# Altium schematic sheet S7CAS-PLEIADES-CARTE-MERE_P07_CLOCK.SchDoc(S7CAS-PLEIADES-CARTE-MERE_P07_CLOCK)
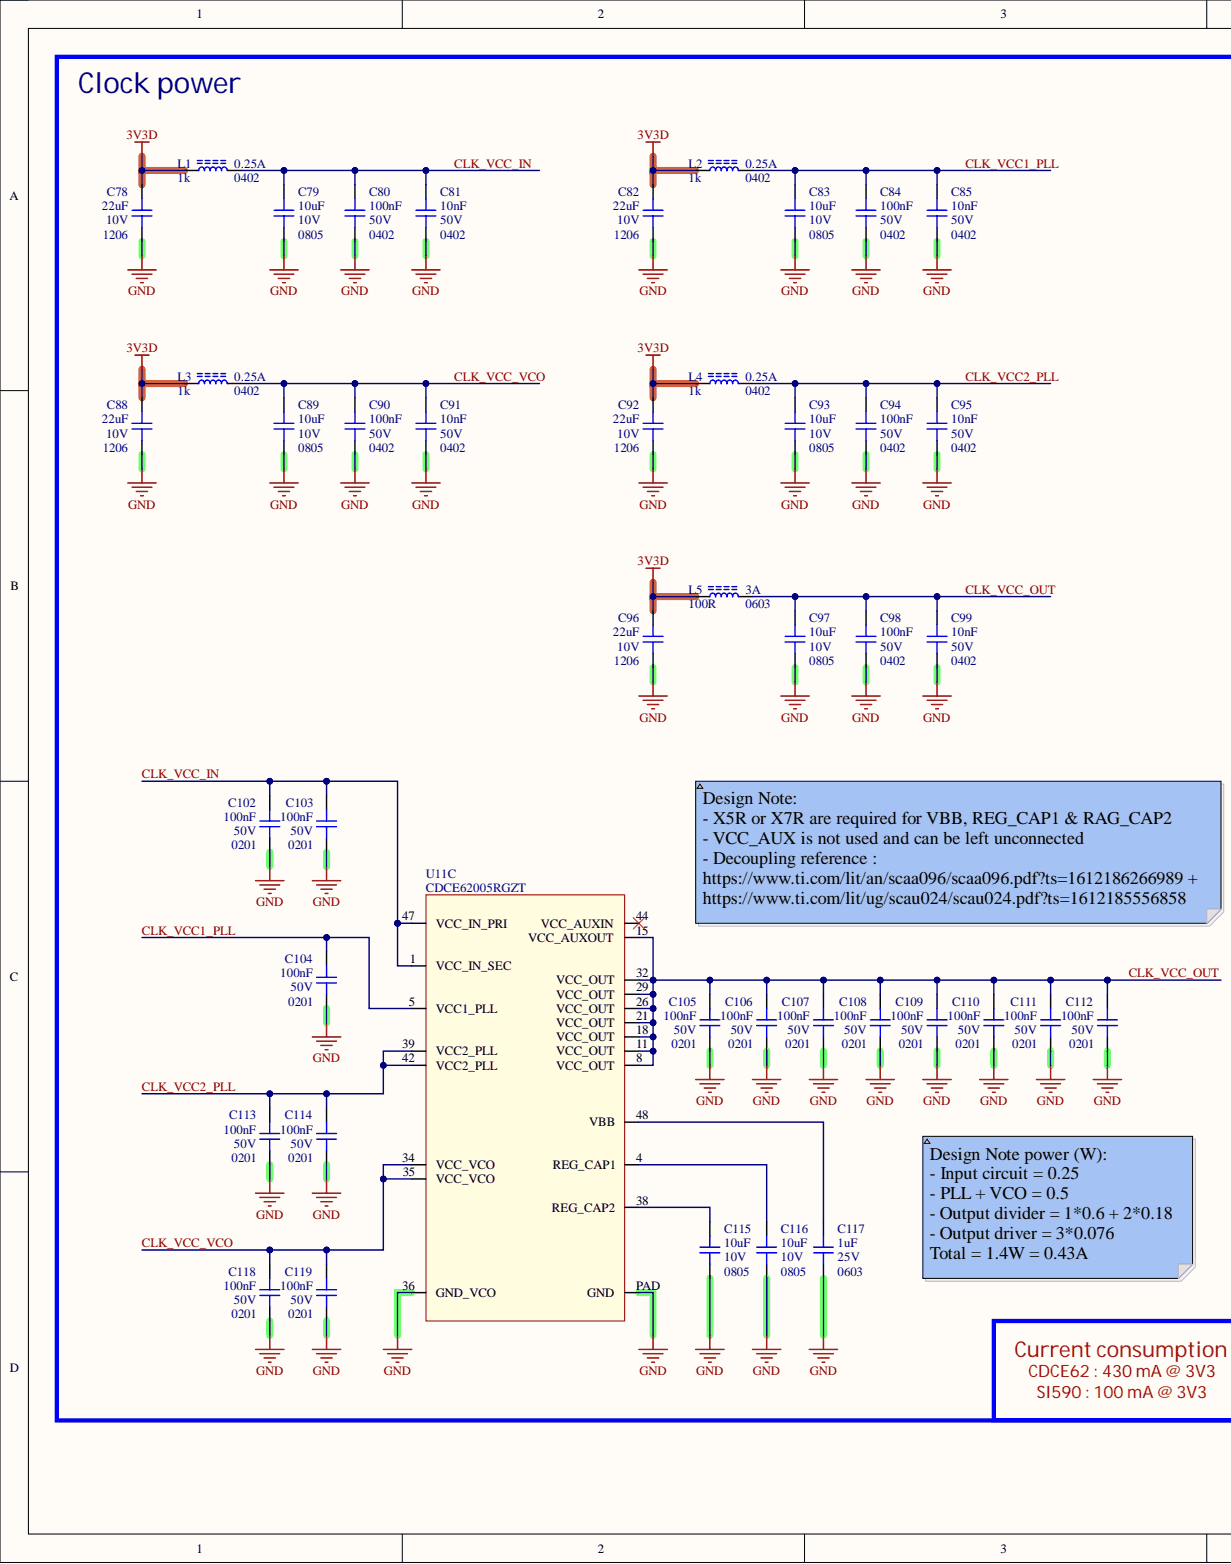
[diagram p0: S7CAS-PLEIADES-CARTE-MERE_P07_CLOCK.SchDoc, left]
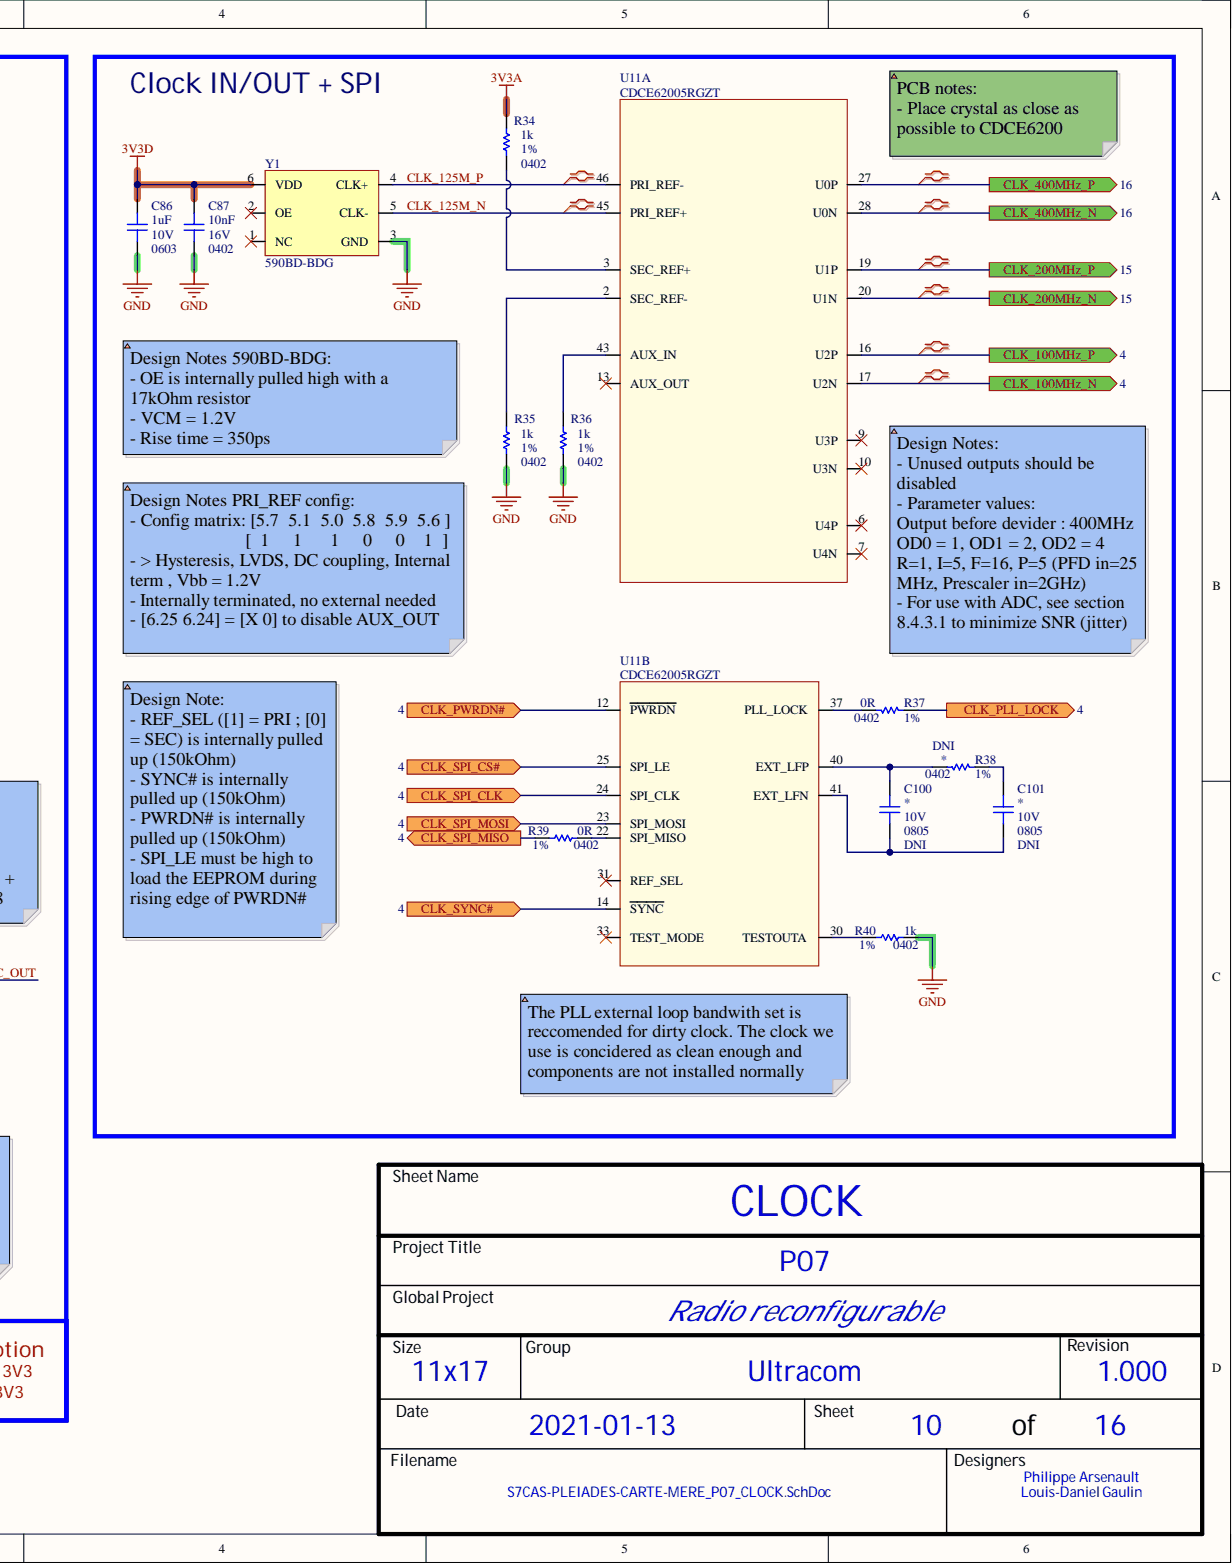
[diagram p1: S7CAS-PLEIADES-CARTE-MERE_P07_CLOCK.SchDoc, right]

## Parts
C78  value 22uF  Package: 1206  Voltage: 10V  [CAP_1206]  (hidden comment: CAP - 1206)
C79  value 10uF  Package: 0805  Voltage: 10V  [CAP_0805]  (hidden comment: CAP - 0805)
C80  value 100nF  Package: 0402  Voltage: 50V  [CAP_0402]  (hidden comment: CAP - 0402)
C81  value 10nF  Package: 0402  Voltage: 50V  [CAP_0402]  (hidden comment: CAP - 0402)
C82  value 22uF  Package: 1206  Voltage: 10V  [CAP_1206]  (hidden comment: CAP - 1206)
C83  value 10uF  Package: 0805  Voltage: 10V  [CAP_0805]  (hidden comment: CAP - 0805)
C84  value 100nF  Package: 0402  Voltage: 50V  [CAP_0402]  (hidden comment: CAP - 0402)
C85  value 10nF  Package: 0402  Voltage: 50V  [CAP_0402]  (hidden comment: CAP - 0402)
C86  value 1uF  Package: 0603  Voltage: 10V  [CAP_0603]  (hidden comment: CAP - 0603)
C87  value 10nF  Package: 0402  Voltage: 16V  [CAP_0402]  (hidden comment: CAP - 0402)
C88  value 22uF  Package: 1206  Voltage: 10V  [CAP_1206]  (hidden comment: CAP - 1206)
C89  value 10uF  Package: 0805  Voltage: 10V  [CAP_0805]  (hidden comment: CAP - 0805)
C90  value 100nF  Package: 0402  Voltage: 50V  [CAP_0402]  (hidden comment: CAP - 0402)
C91  value 10nF  Package: 0402  Voltage: 50V  [CAP_0402]  (hidden comment: CAP - 0402)
C92  value 22uF  Package: 1206  Voltage: 10V  [CAP_1206]  (hidden comment: CAP - 1206)
C93  value 10uF  Package: 0805  Voltage: 10V  [CAP_0805]  (hidden comment: CAP - 0805)
C94  value 100nF  Package: 0402  Voltage: 50V  [CAP_0402]  (hidden comment: CAP - 0402)
C95  value 10nF  Package: 0402  Voltage: 50V  [CAP_0402]  (hidden comment: CAP - 0402)
C96  value 22uF  Package: 1206  Voltage: 10V  [CAP_1206]  (hidden comment: CAP - 1206)
C97  value 10uF  Package: 0805  Voltage: 10V  [CAP_0805]  (hidden comment: CAP - 0805)
C98  value 100nF  Package: 0402  Voltage: 50V  [CAP_0402]  (hidden comment: CAP - 0402)
C99  value 10nF  Package: 0402  Voltage: 50V  [CAP_0402]  (hidden comment: CAP - 0402)
C100  NI: DNI  Package: 0805  Voltage: 10V  [CAP_0805]  (hidden comment: CAP - 0805)
C101  NI: DNI  Package: 0805  Voltage: 10V  [CAP_0805]  (hidden comment: CAP - 0805)
C102  value 100nF  Package: 0201  Voltage: 50V  [CAP_0201]  (hidden comment: CAP - 0201)
C103  value 100nF  Package: 0201  Voltage: 50V  [CAP_0201]  (hidden comment: CAP - 0201)
C104  value 100nF  Package: 0201  Voltage: 50V  [CAP_0201]  (hidden comment: CAP - 0201)
C105  value 100nF  Package: 0201  Voltage: 50V  [CAP_0201]  (hidden comment: CAP - 0201)
C106  value 100nF  Package: 0201  Voltage: 50V  [CAP_0201]  (hidden comment: CAP - 0201)
C107  value 100nF  Package: 0201  Voltage: 50V  [CAP_0201]  (hidden comment: CAP - 0201)
C108  value 100nF  Package: 0201  Voltage: 50V  [CAP_0201]  (hidden comment: CAP - 0201)
C109  value 100nF  Package: 0201  Voltage: 50V  [CAP_0201]  (hidden comment: CAP - 0201)
C110  value 100nF  Package: 0201  Voltage: 50V  [CAP_0201]  (hidden comment: CAP - 0201)
C111  value 100nF  Package: 0201  Voltage: 50V  [CAP_0201]  (hidden comment: CAP - 0201)
C112  value 100nF  Package: 0201  Voltage: 50V  [CAP_0201]  (hidden comment: CAP - 0201)
C113  value 100nF  Package: 0201  Voltage: 50V  [CAP_0201]  (hidden comment: CAP - 0201)
C114  value 100nF  Package: 0201  Voltage: 50V  [CAP_0201]  (hidden comment: CAP - 0201)
C115  value 10uF  Package: 0805  Voltage: 10V  [CAP_0805]  (hidden comment: CAP - 0805)
C116  value 10uF  Package: 0805  Voltage: 10V  [CAP_0805]  (hidden comment: CAP - 0805)
C117  value 1uF  Package: 0603  Voltage: 25V  [CAP_0603]  (hidden comment: CAP - 0603)
C118  value 100nF  Package: 0201  Voltage: 50V  [CAP_0201]  (hidden comment: CAP - 0201)
C119  value 100nF  Package: 0201  Voltage: 50V  [CAP_0201]  (hidden comment: CAP - 0201)
L1  value 1k  Current: 0.25A  Package: 0402  [FER_0402]  (hidden comment: FER - 0402)
L2  value 1k  Current: 0.25A  Package: 0402  [FER_0402]  (hidden comment: FER - 0402)
L3  value 1k  Current: 0.25A  Package: 0402  [FER_0402]  (hidden comment: FER - 0402)
L4  value 1k  Current: 0.25A  Package: 0402  [FER_0402]  (hidden comment: FER - 0402)
L5  value 100R  Current: 3A  Package: 0603  [FER_0603]  (hidden comment: FER - 0603)
R34  value 1k  Package: 0402  Precision: 1%  [RES_0402]  (hidden comment: RES - 0402)
R35  value 1k  Package: 0402  Precision: 1%  [RES_0402]  (hidden comment: RES - 0402)
R36  value 1k  Package: 0402  Precision: 1%  [RES_0402]  (hidden comment: RES - 0402)
R37  value 0R  Package: 0402  Precision: 1%  [RES_0402]  (hidden comment: RES - 0402)
R38  NI: DNI  Package: 0402  Precision: 1%  [RES_0402]  (hidden comment: RES - 0402)
R39  value 0R  Package: 0402  Precision: 1%  [RES_0402]  (hidden comment: RES - 0402)
R40  value 1k  Package: 0402  Precision: 1%  [RES_0402]  (hidden comment: RES - 0402)
U11  CDCE62005RGZT  [TIM_CDCE62005RGZT]  (parts A,B,C)
Y1  590BD-BDG  [OSC_590BD-BDG]

## Ports
CLK_100MHz_N, CLK_100MHz_P, CLK_200MHz_N, CLK_200MHz_P, CLK_400MHz_N, CLK_400MHz_P, CLK_PLL_LOCK, CLK_PWRDN#, CLK_SPI_CLK, CLK_SPI_CS#, CLK_SPI_MISO, CLK_SPI_MOSI, CLK_SYNC#

## Nets
3V3A: R34.2
3V3D: C78.2 C82.2 C86.2 C87.2 C88.2 C92.2 C96.2 L1.2 L2.2 L3.2 L4.2 L5.2 Y1.6(VDD)
GND: C78.1 C79.1 C80.1 C81.1 C82.1 C83.1 C84.1 C85.1 C86.1 C87.1 C88.1 C89.1 C90.1 C91.1 C92.1 C93.1 C94.1 C95.1 C96.1 C97.1 C98.1 C99.1 C102.1 C103.1 C104.1 C105.1 C106.1 C107.1 C108.1 C109.1 C110.1 C111.1 C112.1 C113.1 C114.1 C115.1 C116.1 C117.1 C118.1 C119.1 R35.1 R36.1 R40.1 U11.36(GND_VCO) U11.PAD(GND) Y1.3(GND)
CLK_100MHz_N: U11.17(U2N) ; port CLK_100MHz_N
CLK_100MHz_P: U11.16(U2P) ; port CLK_100MHz_P
CLK_125M_N: U11.45(PRI_REF+) Y1.5(CLK-)
CLK_125M_P: U11.46(PRI_REF-) Y1.4(CLK+)
CLK_200MHz_N: U11.20(U1N) ; port CLK_200MHz_N
CLK_200MHz_P: U11.19(U1P) ; port CLK_200MHz_P
CLK_400MHz_N: U11.28(U0N) ; port CLK_400MHz_N
CLK_400MHz_P: U11.27(U0P) ; port CLK_400MHz_P
CLK_PLL_LOCK: R37.2 ; port CLK_PLL_LOCK
CLK_PWRDN#: U11.12(PWRDN) ; port CLK_PWRDN#
CLK_SPI_CLK: U11.24(SPI_CLK) ; port CLK_SPI_CLK
CLK_SPI_CS#: U11.25(SPI_LE) ; port CLK_SPI_CS#
CLK_SPI_MISO: R39.2 ; port CLK_SPI_MISO
CLK_SPI_MOSI: U11.23(SPI_MOSI) ; port CLK_SPI_MOSI
CLK_SYNC#: U11.14(SYNC) ; port CLK_SYNC#
CLK_VCC1_PLL: C83.2 C84.2 C85.2 C104.2 L2.1 U11.5(VCC1_PLL)
CLK_VCC2_PLL: C93.2 C94.2 C95.2 C113.2 C114.2 L4.1 U11.39(VCC2_PLL) U11.42(VCC2_PLL)
CLK_VCC_IN: C79.2 C80.2 C81.2 C102.2 C103.2 L1.1 U11.1(VCC_IN_SEC) U11.47(VCC_IN_PRI)
CLK_VCC_OUT: C97.2 C98.2 C99.2 C105.2 C106.2 C107.2 C108.2 C109.2 C110.2 C111.2 C112.2 L5.1 U11.8(VCC_OUT) U11.11(VCC_OUT) U11.15(VCC_AUXOUT) U11.18(VCC_OUT) U11.21(VCC_OUT) U11.26(VCC_OUT) U11.29(VCC_OUT) U11.32(VCC_OUT)
CLK_VCC_VCO: C89.2 C90.2 C91.2 C118.2 C119.2 L3.1 U11.34(VCC_VCO) U11.35(VCC_VCO)
NetC100_1: C100.1 C101.1 U11.41(EXT_LFN)
NetC100_2: C100.2 R38.1 U11.40(EXT_LFP)
NetC101_2: C101.2 R38.2
NetC115_2: C115.2 U11.38(REG_CAP2)
NetC116_2: C116.2 U11.4(REG_CAP1)
NetC117_2: C117.2 U11.48(VBB)
NetR34_1: R34.1 U11.3(SEC_REF+)
NetR35_2: R35.2 U11.2(SEC_REF-)
NetR36_2: R36.2 U11.43(AUX_IN)
NetR37_1: R37.1 U11.37(PLL_LOCK)
NetR39_1: R39.1 U11.22(SPI_MISO)
NetR40_2: R40.2 U11.30(TESTOUTA)

Not connected: U11 6(U4P) 7(U4N) 9(U3P) 10(U3N) 13(AUX_OUT) 31(REF_SEL) 33(TEST_MODE) 44(VCC_AUXIN); Y1 1(NC) 2(OE)

## Library data of the parts
C78 C82 C88 C92 C96 = CAP - 1206: Precision: 10%; Component Kind: Standard; Description: CAP - 1206; Library Name: S7CAS_LIB2021.IntLib; CreatedBy: Jonathan Bouchard
C79 C83 C89 C93 C97 C100 C101 C115 C116 = CAP - 0805: Mfg: CL21B106KPQNNNE; Precision: 10%; Component Kind: Standard; Description: CAP - 0805; Library Name: S7CAS_LIB2021.IntLib; CreatedBy: Jonathan Bouchard
C80 C81 C84 C85 C87 C90 C91 C94 C95 C98 C99 = CAP - 0402: Precision: 10%; Component Kind: Standard; Description: CAP - 0402; Library Name: S7CAS_LIB2021.IntLib; CreatedBy: Jonathan Bouchard
C86 = CAP - 0603: Precision: 10%; Component Kind: Standard; Description: CAP - 0603; Library Name: S7CAS_LIB2021.IntLib; CreatedBy: Jonathan Bouchard
C102-C114 C118 C119 = CAP - 0201: Precision: 10%; Component Kind: Standard; Description: CAP - 0201; Library Name: S7CAS_LIB2021.IntLib; CreatedBy: Jonathan Bouchard
C117 = CAP - 0603: Mfg Part Number: CC0603KRX5R8BB105; Precision: 10%; Component Kind: Standard; Description: CAP - 0603; Library Name: S7CAS_LIB2021.IntLib; CreatedBy: Jonathan Bouchard
L1-L4 = FER - 0402: Mfg Part Number: BLM15HD102SN1D; Component Kind: Standard; Description: FER - 0402; Library Name: S7CAS_LIB2021.IntLib; CreatedBy: Jonathan Bouchard
L5 = FER - 0603: Mfg Part Number: BLM18KG101TN1D; Component Kind: Standard; Description: FER - 0603; Library Name: S7CAS_LIB2021.IntLib; CreatedBy: Jonathan Bouchard
R34-R40 = RES - 0402: Power: 1/16W; Voltage: 50V; Component Kind: Standard; Description: RES - 0402; Library Name: S7CAS_LIB2021.IntLib; CreatedBy: Jonathan Bouchard
U11 = TIM_CDCE62005RGZT: TIM - CLK SYNTH JITTER CLEANER 3:5 - QFN-48; Mfg: Texas Instruments; Voltage: 3 V ~ 3.6 V; Component Kind: Standard; HelpURL: http://www.ti.com/lit/ds/symlink/cdce62005.pdf; Library Name: S7CAS_LIB2021.IntLib; Mfg Part Number: CDCE62005RGZT; Package: QFN-48; Supplier 1: Digikey; Supplier Part Number 1: 296-23947-1-ND; Temperature: -40°C ~ 85°C; CreatedBy: Jonathan Bouchard
Y1 = OSC_590BD-BDG: TIM - OSC PROG 125MHz ~ 214.999MHz LVDS 3.3V 7PPM EN/DS - 6-SMD; Manufacturer #1: Silicon Labs; Cryo: UNKNOWN; Current Rating: 100 mA (supply); GRAMS Lib Spec: 2.8; Note: Spécifié la fréquence lors de la commande; Polarized: Yes; Value: ±7ppm (freq Stability), ±20ppm (freq stability total); Voltage rating: 3.3V (supply); Assembly Type: SMD; Component Kind: Standard; HelpURL: https://www.silabs.com/documents/public/data-sheets/Si590-591.pdf; Library Name: S7CAS_LIB2021.IntLib; Magnetic Material: UNKNOWN; Package: 6-SMD; Part Number #1: 590BD-BDG; Supplier 1: Digi-Key; Supplier Part Number 1: 590BD-BDG-ND; Temperature: -40°C ~ 85°C; CreatedBy/Date: from 590BD-CDG; VerifiedBy: Caroline Paulin / 3/10/2019
